# Altium schematic sheet trigger_.SchDoc
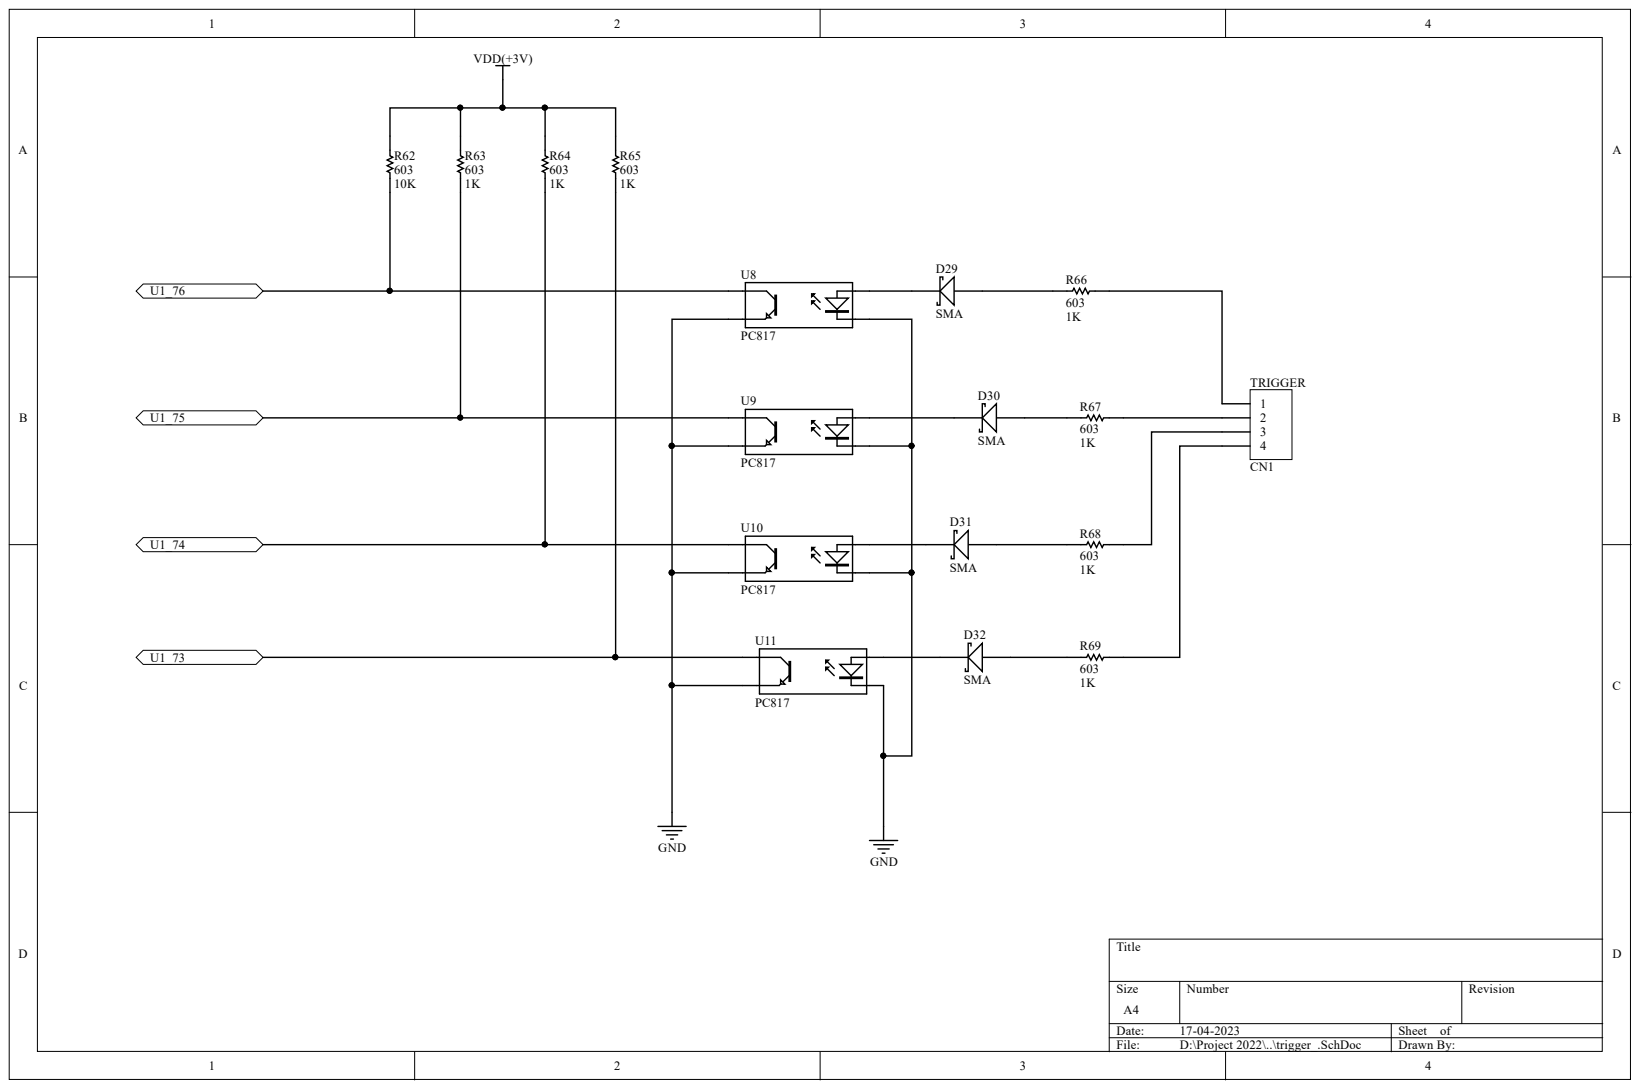
[diagram p0: trigger_.SchDoc]

## Parts
D29  SMA  [SMB]
D30  SMA  [SMB]
D31  SMA  [SMB]
D32  SMA  [SMB]
R62  603  value 10K  [AXIAL-0.3]
R63  603  value 1K  [AXIAL-0.3]
R64  603  value 1K  [AXIAL-0.3]
R65  603  value 1K  [AXIAL-0.3]
R66  603  value 1K  [AXIAL-0.3]
R67  603  value 1K  [AXIAL-0.3]
R68  603  value 1K  [AXIAL-0.3]
R69  603  value 1K  [AXIAL-0.3]
TRIGGER  CN1  [HDR1X4]
U8  PC817  [DIP-4]
U9  PC817  [DIP-4]
U10  PC817  [DIP-4]
U11  PC817  [DIP-4]

## Ports
U1_73, U1_74, U1_75, U1_76

## Nets
GND: U8.2 U8.3 U9.2 U9.3 U10.2 U10.3 U11.2 U11.3
VDD(+3V): R62.2 R63.2 R64.2 R65.2
U1_73: R65.1 U11.4 ; port U1_73
U1_74: R64.1 U10.4 ; port U1_74
U1_75: R63.1 U9.4 ; port U1_75
U1_76: R62.1 U8.4 ; port U1_76
NetD29_1: D29.1 R66.2
NetD29_2: D29.2 U8.1
NetD30_1: D30.1 R67.2
NetD30_2: D30.2 U9.1
NetD31_1: D31.1 R68.2
NetD31_2: D31.2 U10.1
NetD32_1: D32.1 R69.2
NetD32_2: D32.2 U11.1
NetR66_1: R66.1 TRIGGER.1
NetR67_1: R67.1 TRIGGER.2
NetR68_1: R68.1 TRIGGER.3
NetR69_1: R69.1 TRIGGER.4

## Library data of the parts
D29-D32 = D Schottky: Schottky Diode; Code_JEDEC: DO-214-AA; Component Kind: Standard; LatestRevisionDate: 17-Jul-2002; LatestRevisionNote: Re-released for DXP Platform.; Library Name: Miscellaneous Devices.IntLib; PackageDescription: DO-214-AA/SMB; 2 C-Bend Leads; Body 5.3 x 3.6 mm, inc. leads (LxW); PackageReference: SMB; Published: 8-Jun-2000; Signal Integrity: D Schottky; Simulation: SKYDIODE; Publisher: Altium Limited
R62-R69 = Res1: Resistor; Component Kind: Standard; LatestRevisionDate: 17-Jul-2002; LatestRevisionNote: Re-released for DXP Platform.; Library Name: Miscellaneous Devices.IntLib; PackageDescription: Axial Device, Thru-Hole; 2 Leads; 0.3 in Pin Spacing; PackageReference: AXIAL-0.3; Published: 8-Jun-2000; Signal Integrity: Res; Simulation: RESISTOR; Publisher: Altium Limited
TRIGGER = Header 4: Header, 4-Pin; Component Kind: Standard; LatestRevisionDate: 17-Jul-2002; LatestRevisionNote: Re-released for DXP Platform.; Library Name: Miscellaneous Connectors.IntLib; Signal Integrity: Connector; Publisher: Altium Limited
U8-U11 = Optoisolator1: 4-Pin Phototransistor Optocoupler; Component Kind: Standard; DatasheetVersion: Nov-2003; LatestRevisionDate: 04-Mar-2005; LatestRevisionNote: Sch pins 3 & 4 Swapped.; Library Name: Miscellaneous Devices.IntLib; PackageReference: DIP-4; Published: 24-Mar-1999; Publisher: Altium Limited
